# Altium schematic sheet EEG_schematic.SchDoc(EEG_schematic) (continued)

## Library data of the parts
C1 C4 C6 C15 C16 = Cap: Capacitor; Manufacturer Part Number: GRM153R61A105ME95D; Manufacturer: Murata; Voltage Rating (DC): 10V; Tolerance: 20%; Material: Ceramic; Dielectric: X5R; Width: 0.5mm; Weight: 0.000212oz; Voltage Rating: 10V; Thickness: 0.013inch; Termination: SMD/SMT; Supplier Part Number 1: 490-14577-6-ND; Supplier 1: Digi-Key; Stock 1: 47503; Simulation: CAP; Signal Integrity: Cap; REACH SVHC: No SVHC; Published: 8-Jun-2000; Pricing 1: 1=0.19, 10=0.131, 100=0.0663, 500=0.04538, 1000=0.04015, 2500=0.0384, 5000=0.03491 (USD); Packaging: Tape and Reel; PackageReference: RAD-0.3; Package Quantity: 10000; PackageDescription: Radial Cap, Thru-Hole; 2 Leads; 0.3 in Pin Spacing; Mount: Surface Mount; Min Operating Temperature: -55°C; Max Operating Temperature: 85°C; Library Name: Miscellaneous Devices.IntLib; Length: 1mm; LatestRevisionNote: Re-released for DXP Platform.; LatestRevisionDate: 17-Jul-2002; Height: 0.3mm; Composition: Ceramic; ComponentLink1URL: http://www.farnell.com/datasheets/1666664.pdf?src-supplier=Element14; ComponentLink1Description: http://www.farnell.com/datasheets/1666664.pdf?src-supplier=Element14; Component Kind: Standard; Case Code (Metric): 1005; Case Code (Imperial): 0402; Case/Package: 0402; Capacitance: 1uF; Publisher: Altium Limited
C2 C5 C7 C10 C12 C18 = Cap: Capacitor; Manufacturer Part Number: CL05A104KP5NNNC; Manufacturer: Samsung; Voltage Rating: 10V; Tolerance: 10%; Dielectric: X5R; Capacitance: 100nF; Width: 0.02inch; Thickness: 0.022inch; Supplier Part Number 1: 1276-1022-6-ND; Supplier 1: Digi-Key; Stock 1: 13743377; Simulation: CAP; Signal Integrity: Cap; Radiation Hardening: No; Published: 8-Jun-2000; Pricing 1: 1=0.1, 10=0.025, 100=0.0111, 500=0.00794, 1000=0.00624, 2500=0.00568, 5000=0.00522 (USD); Packaging: Tape and Reel; PackageReference: RAD-0.3; PackageDescription: Radial Cap, Thru-Hole; 2 Leads; 0.3 in Pin Spacing; Mount: Surface Mount; Min Operating Temperature: -55°C; Max Operating Temperature: 85°C; Library Name: Miscellaneous Devices.IntLib; Length: 1mm; LatestRevisionNote: Re-released for DXP Platform.; LatestRevisionDate: 17-Jul-2002; Height: 0.5mm; Depth: 0.5mm; Contact Plating: Tin; ComponentLink4URL: https://www.distrelec.biz/Web/Downloads/_e/ng/MLCC_CatalogDownload2013_ENG.pdf?mime=application%2Fpdf&src-supplier=Distrelec; ComponentLink4Description: https://www.distrelec.biz/Web/Downloads/_e/ng/MLCC_CatalogDownload2013_ENG.pdf?mime=application%2Fpdf&src-supplier=Distrelec; ComponentLink3URL: http://www1.futureelectronics.com/doc/SAMSUNG%20PASSIVE/CL05B104KQ5NNNC.pdf?src-supplier=Future+Electronics; ComponentLink3Description: http://www1.futureelectronics.com/doc/SAMSUNG%20PASSIVE/CL05B104KQ5NNNC.pdf?src-supplier=Future+Electronics; ComponentLink2URL: https://www.verical.com/server-webapp/html/getDatasheet?partID=358611&src-supplier=Verical; ComponentLink2Description: https://www.verical.com/server-webapp/html/getDatasheet?partID=358611&src-supplier=Verical; ComponentLink1URL: https://media.digikey.com/pdf/Data%20Sheets/Samsung%20PDFs/CL_Series_MLCC_ds.pdf?src-supplier=Digi-Key; ComponentLink1Description: https://media.digikey.com/pdf/Data%20Sheets/Samsung%20PDFs/CL_Series_MLCC_ds.pdf?src-supplier=Digi-Key; Component Kind: Standard; Case Code (Metric): 1005; Case Code (Imperial): 0402; Case/Package: 0402; Publisher: Altium Limited
C3 = Cap: Capacitor; Manufacturer Part Number: CL05B152KB5NNNC; Manufacturer: Samsung; Voltage Rating: 50V; Tolerance: 10%; Material: Ceramic; Dielectric: X7R; Width: 0.02inch; Voltage: 50V; Thickness: 0.022inch; Supplier Part Number 1: 1276-1521-6-ND; Supplier 1: Digi-Key; Stock 1: 680460; Simulation: CAP; Signal Integrity: Cap; Radiation Hardening: No; Published: 8-Jun-2000; Pricing 1: 1=0.1, 10=0.023, 100=0.0101, 500=0.0072, 1000=0.00566, 2500=0.00514, 5000=0.00473 (USD); Packaging: Tape and Reel; PackageReference: RAD-0.3; Package Quantity: 1; PackageDescription: Radial Cap, Thru-Hole; 2 Leads; 0.3 in Pin Spacing; Mount: Surface Mount; Min Operating Temperature: -55°C; Max Operating Temperature: 125°C; Library Name: Miscellaneous Devices.IntLib; Length: 1mm; LatestRevisionNote: Re-released for DXP Platform.; LatestRevisionDate: 17-Jul-2002; Height: 0.5mm; Depth: 0.5mm; Contact Plating: Tin; ComponentLink4URL: https://produktinfo.conrad.com/datenblaetter/450000-474999/459134-da-01-en-VS_KONDENSATOR_0402.pdf?src-supplier=Conrad; ComponentLink4Description: https://produktinfo.conrad.com/datenblaetter/450000-474999/459134-da-01-en-VS_KONDENSATOR_0402.pdf?src-supplier=Conrad; ComponentLink3URL: https://www.distrelec.biz/Web/Downloads/_e/ng/MLCC_CatalogDownload2013_ENG.pdf?mime=application%2Fpdf&src-supplier=Distrelec; ComponentLink3Description: https://www.distrelec.biz/Web/Downloads/_e/ng/MLCC_CatalogDownload2013_ENG.pdf?mime=application%2Fpdf&src-supplier=Distrelec; ComponentLink2URL: http://www1.futureelectronics.com/doc/SAMSUNG/CL05B152KB5NNNC.pdf?src-supplier=Future+Electronics; ComponentLink2Description: http://www1.futureelectronics.com/doc/SAMSUNG/CL05B152KB5NNNC.pdf?src-supplier=Future+Electronics; ComponentLink1URL: https://www.verical.com/server-webapp/html/getDatasheet?partID=543173&src-supplier=Verical; ComponentLink1Description: https://www.verical.com/server-webapp/html/getDatasheet?partID=543173&src-supplier=Verical; Component Kind: Standard; Case Code (Metric): 1005; Case Code (Imperial): 0402; Case/Package: 0402; Capacitance: 1.5nF; Publisher: Altium Limited
C8 C13 C14 = Cap: Capacitor; Manufacturer Part Number: GRM155R61A475MEAAD; Manufacturer: Murata; Voltage Rating: 10V; Tolerance: 20%; Supplier Part Number 1: 490-14306-6-ND; Supplier 1: Digi-Key; Stock 1: 986807; Simulation: CAP; Signal Integrity: Cap; Published: 8-Jun-2000; Pricing 1: 1=0.25, 10=0.169, 100=0.0856, 500=0.05854, 1000=0.05179, 2500=0.04954, 5000=0.04503 (USD); Packaging: Tape and Reel; PackageReference: RAD-0.3; PackageDescription: Radial Cap, Thru-Hole; 2 Leads; 0.3 in Pin Spacing; Mount: Surface Mount; Min Operating Temperature: -55°C; Max Operating Temperature: 85°C; Library Name: Miscellaneous Devices.IntLib; LatestRevisionNote: Re-released for DXP Platform.; LatestRevisionDate: 17-Jul-2002; ComponentLink10URL: http://datasheet.octopart.com/GRM155R61A475MEAAD-Murata-datasheet-12515295.pdf; ComponentLink10Description: http://datasheet.octopart.com/GRM155R61A475MEAAD-Murata-datasheet-12515295.pdf; ComponentLink9URL: http://datasheet.octopart.com/GRM155R61A475MEAAD-Murata-datasheet-12510076.pdf; ComponentLink9Description: http://datasheet.octopart.com/GRM155R61A475MEAAD-Murata-datasheet-12510076.pdf; ComponentLink8URL: http://datasheet.octopart.com/GRM155R61A475MEAAD-Murata-datasheet-11978611.pdf; ComponentLink8Description: http://datasheet.octopart.com/GRM155R61A475MEAAD-Murata-datasheet-11978611.pdf; ComponentLink7URL: http://datasheet.octopart.com/GRM155R61A475MEAAD-Murata-datasheet-10025737.pdf; ComponentLink7Description: http://datasheet.octopart.com/GRM155R61A475MEAAD-Murata-datasheet-10025737.pdf; ComponentLink6URL: http://datasheet.octopart.com/GRM155R61A475MEAAD-Murata-datasheet-8819587.pdf; ComponentLink6Description: http://datasheet.octopart.com/GRM155R61A475MEAAD-Murata-datasheet-8819587.pdf; ComponentLink5URL: http://datasheet.octopart.com/GRM155R61A475MEAAD-Murata-datasheet-68589269.pdf?src-supplier=Newark; ComponentLink5Description: http://datasheet.octopart.com/GRM155R61A475MEAAD-Murata-datasheet-68589269.pdf?src-supplier=Newark; ComponentLink4URL: http://www.farnell.com/datasheets/2189109.pdf?src-supplier=Newark; ComponentLink4Description: http://www.farnell.com/datasheets/2189109.pdf?src-supplier=Newark; ComponentLink3URL: http://www.farnell.com/datasheets/2189109.pdf?src-supplier=Element14; ComponentLink3Description: http://www.farnell.com/datasheets/2189109.pdf?src-supplier=Element14; ComponentLink2URL: https://search.murata.co.jp/Ceramy/image/img/A01X/G101/ENG/GRM155R61A475MEAA-01.pdf?src-supplier=Digi-Key; ComponentLink2Description: https://search.murata.co.jp/Ceramy/image/img/A01X/G101/ENG/GRM155R61A475MEAA-01.pdf?src-supplier=Digi-Key; ComponentLink1URL: http://www.farnell.com/datasheets/2189109.pdf?src-supplier=Farnell; ComponentLink1Description: http://www.farnell.com/datasheets/2189109.pdf?src-supplier=Farnell; Component Kind: Standard; Case Code (Metric): 1005; Case Code (Imperial): 0402; Case/Package: 0402; Capacitance: 4.7uF; Publisher: Altium Limited
C9 C11 C17 C22 = Cap: Capacitor; Manufacturer Part Number: CL05A106MP5NUNC; Manufacturer: Samsung; Voltage Rating: 10V; Tolerance: 20%; Dielectric: X5R; Width: 0.02inch; Thickness: 0.028inch; Termination: Wraparound; Supplier Part Number 1: 1276-1450-6-ND; Supplier 1: Digi-Key; Stock 1: 3732371; Simulation: CAP; Signal Integrity: Cap; Radiation Hardening: No; Published: 8-Jun-2000; Pricing 1: 1=0.42, 10=0.295, 100=0.1943, 500=0.14392, 1000=0.12233, 2500=0.11514, 5000=0.10794 (USD); Packaging: Tape and Reel; PackageReference: RAD-0.3; Package Quantity: 1; PackageDescription: Radial Cap, Thru-Hole; 2 Leads; 0.3 in Pin Spacing; Mount: Surface Mount; Min Operating Temperature: -55°C; Max Operating Temperature: 85°C; Library Name: Miscellaneous Devices.IntLib; Length: 1mm; Lead Free: Lead Free; LatestRevisionNote: Re-released for DXP Platform.; LatestRevisionDate: 17-Jul-2002; Depth: 0.5mm; Contact Plating: Tin; ComponentLink2URL: https://www.verical.com/server-webapp/html/getDatasheet?partID=745310&src-supplier=Verical; ComponentLink2Description: https://www.verical.com/server-webapp/html/getDatasheet?partID=745310&src-supplier=Verical; ComponentLink1URL: https://media.digikey.com/pdf/Data%20Sheets/Samsung%20PDFs/CL_Series_MLCC_ds.pdf?src-supplier=Digi-Key; ComponentLink1Description: https://media.digikey.com/pdf/Data%20Sheets/Samsung%20PDFs/CL_Series_MLCC_ds.pdf?src-supplier=Digi-Key; Component Kind: Standard; Case Code (Metric): 1005; Case Code (Imperial): 0402; Case/Package: 0402; Capacitance: 10uF; Publisher: Altium Limited
C19 C23 = Cap: Capacitor; Manufacturer Part Number: GRM31CR60J107ME39L; Manufacturer: Murata; Voltage Rating (DC): 6.3V; Tolerance: 20%; Material: Ceramic; Dielectric: X5R; Width: 1.6mm; Voltage Rating: 6.3V; Voltage: 6.3V; Thickness: 0.071inch; Termination: SMD/SMT; Supplier Part Number 1: 490-4539-6-ND; Supplier 1: Digi-Key; Stock 1: 1563525; Simulation: CAP; Signal Integrity: Cap; REACH SVHC: No SVHC; Radiation Hardening: No; Published: 8-Jun-2000; Pricing 1: 1=1.08, 10=0.852, 100=0.6386, 500=0.48254, 1000=0.42577 (USD); Packaging: Tape and Reel; PackageReference: RAD-0.3; Package Quantity: 1; PackageDescription: Radial Cap, Thru-Hole; 2 Leads; 0.3 in Pin Spacing; Mount: Surface Mount; Min Operating Temperature: -55°C; Max Operating Temperature: 85°C; Library Name: Miscellaneous Devices.IntLib; Length: 3.2mm; Lead Free: Lead Free; LatestRevisionNote: Re-released for DXP Platform.; LatestRevisionDate: 17-Jul-2002; Height: 1.6mm; Depth: 1.6mm; ComponentLink8URL: http://www.produktinfo.conrad.com/datenblaetter/450000-474999/451152-da-01-de-VS_CHIP_KOND_1206_100_0_UF_20Pr_6_3V_X5R.pdf?src-supplier=Conrad; ComponentLink8Description: http://www.produktinfo.conrad.com/datenblaetter/450000-474999/451152-da-01-de-VS_CHIP_KOND_1206_100_0_UF_20Pr_6_3V_X5R.pdf?src-supplier=Conrad; ComponentLink7URL: http://www.produktinfo.conrad.com/datenblaetter/450000-474999/454804-da-01-en-VS_KONDENSATOR_1206.pdf?src-supplier=Conrad; ComponentLink7Description: http://www.produktinfo.conrad.com/datenblaetter/450000-474999/454804-da-01-en-VS_KONDENSATOR_1206.pdf?src-supplier=Conrad; ComponentLink6URL: https://www.distrelec.biz/Web/Downloads/_t/ds/murata_MLCC_eng_tds.pdf?mime=application%2Fpdf&src-supplier=Distrelec; ComponentLink6Description: https://www.distrelec.biz/Web/Downloads/_t/ds/murata_MLCC_eng_tds.pdf?mime=application%2Fpdf&src-supplier=Distrelec; ComponentLink5URL: http://psearch.murata.com/capacitor/product/GRM31CF51A226ZE01%23.pdf?src-supplier=Future+Electronics; ComponentLink5Description: http://psearch.murata.com/capacitor/product/GRM31CF51A226ZE01%23.pdf?src-supplier=Future+Electronics; ComponentLink4URL: https://www.murata.com/~/media/webrenewal/support/library/catalog/products/capacitor/mlcc/c02e.ashx?la=en-us&src-supplier=Digi-Key; ComponentLink4Description: https://www.murata.com/~/media/webrenewal/support/library/catalog/products/capacitor/mlcc/c02e.ashx?la=en-us&src-supplier=Digi-Key; ComponentLink3URL: http://www.mouser.com/ds/2/281/c02e-2905.pdf?src-supplier=Mouser; ComponentLink3Description: http://www.mouser.com/ds/2/281/c02e-2905.pdf?src-supplier=Mouser; ComponentLink2URL: http://components.arrow.com/part/dataview/42788059S8888100N2606?src-supplier=Arrow; ComponentLink2Description: http://components.arrow.com/part/dataview/42788059S8888100N2606?src-supplier=Arrow; ComponentLink1URL: http://uk.rs-online.com/webdocs/1388/0900766b813885c5.pdf?src-supplier=RSComponents; ComponentLink1Description: http://uk.rs-online.com/webdocs/1388/0900766b813885c5.pdf?src-supplier=RSComponents; Component Kind: Standard; Case Code (Metric): 3216; Case Code (Imperial): 1206; Case/Package: 1206; Capacitance: 100uF; Publisher: Altium Limited
C20 C21 = Cap: Capacitor; Manufacturer Part Number: C0402C180K5RAC7867; Manufacturer: KEMET; Supplier Part Number 1: 399-19371-6-ND; Supplier 1: Digi-Key; Stock 1: 3803; Simulation: CAP; Signal Integrity: Cap; Published: 8-Jun-2000; Pricing 1: 1=0.21, 10=0.142, 100=0.0721, 500=0.04934, 1000=0.04364, 2500=0.04174, 5000=0.03795 (USD); PackageReference: RAD-0.3; PackageDescription: Radial Cap, Thru-Hole; 2 Leads; 0.3 in Pin Spacing; Library Name: Miscellaneous Devices.IntLib; LatestRevisionNote: Re-released for DXP Platform.; LatestRevisionDate: 17-Jul-2002; Component Kind: Standard; Publisher: Altium Limited
D1 = GSOT04C-G3-08: TVS Diode Arrays 4.0 Volt 300 Watt Common Anode; Manufacturer: Vishay Semiconductors; Reverse Standoff Voltage: 4V; Power Line Protection: No; Polarity: Bidirectional; Peak Pulse Power: 429W; Peak Pulse Current: 30A; Number of Unidirectional Channels: 2; Min Breakdown Voltage: 5V; Clamping Voltage: 14.3V; Capacitance: 200pF; Breakdown Voltage: 6.1V; Weight: 0.00031oz; Termination: SMD/SMT; Supplier Part Number 1: GSOT04C-G3-08GIDKR-ND; Supplier 1: Digi-Key; Stock 1: 3725; Pricing 1: 1=0.43, 10=0.324, 25=0.2916, 100=0.1538, 250=0.15224, 500=0.13634, 1000=0.1061 (USD); Packaging: Tape and Reel; Number of Channels: 2; Mount: Surface Mount; Min Operating Temperature: -55°C; Max Operating Temperature: 150°C; Manufacturer Part Number: GSOT04C-G3-08; Library Name: SchlibEEG.SchLib; Composition: Zener; ComponentLink2URL: http://www.vishay.com/docs/85824/gsot03c.pdf?src-supplier=Digi-Key; ComponentLink2Description: http://www.vishay.com/docs/85824/gsot03c.pdf?src-supplier=Digi-Key; ComponentLink1URL: http://www.mouser.com/ds/2/427/gsot03c-243311.pdf?src-supplier=Mouser; ComponentLink1Description: http://www.mouser.com/ds/2/427/gsot03c-243311.pdf?src-supplier=Mouser; Component Kind: Standard; Case/Package: TO-236-3
D2 = LED1: LED BLUE CLEAR CHIP SMD; Manufacturer Part Number: LTST-C193TBKT-5A; Manufacturer: Vishay Lite-On; Viewing Angle: 130°; Test Current: 5mA; Reverse Voltage: 5V; Power Dissipation: 76mW; Number of LEDs: 1; Luminous Intensity: 15mcd; Lens Style: Diffused,Colorless,Rectangular,Clear; Lens Color: Colorless; Forward Voltage: 2.8V; Forward Current: 20mA; Dominant Wavelength: 475nm; Width: 0.8mm; Supplier Part Number 1: 160-1827-1-ND; Supplier 1: Digi-Key; Stock 1: 40029; Radiation Hardening: No; Published: 8-Jun-2000; Pricing 1: 1=0.45, 10=0.32, 100=0.1424, 500=0.09968, 1000=0.08188, 2500=0.0712 (USD); Packaging: Tape and Reel; PackageReference: LED-1; Package Quantity: 1; PackageDescription: LED; 2 Leads; Number of Pins: 2; Number of Elements: 1; Mount: Surface Mount; Min Operating Temperature: -20°C; Max Operating Temperature: 80°C; Library Name: Miscellaneous Devices.IntLib; Lens Transparency: Clear; Length: 1.6mm; Lead Free: Lead Free; LatestRevisionNote: Mech Layer 1 removed.; LatestRevisionDate: 08-Jul-2005; Illumination Color: Blue; Height: 0.35mm; Depth: 0.8mm; ComponentLink5URL: http://www1.futureelectronics.com/doc/LITE-ON/LTST-C193TBKT-5A.pdf?src-supplier=Future+Electronics; ComponentLink5Description: http://www1.futureelectronics.com/doc/LITE-ON/LTST-C193TBKT-5A.pdf?src-supplier=Future+Electronics; ComponentLink4URL: http://uk.rs-online.com/webdocs/0dc0/0900766b80dc0554.pdf?src-supplier=RSComponents; ComponentLink4Description: http://uk.rs-online.com/webdocs/0dc0/0900766b80dc0554.pdf?src-supplier=RSComponents; ComponentLink3URL: https://www.verical.com/server-webapp/html/getDatasheet?partID=293056&src-supplier=Verical; ComponentLink3Description: https://www.verical.com/server-webapp/html/getDatasheet?partID=293056&src-supplier=Verical; ComponentLink2URL: https://media.digikey.com/pdf/Data%20Sheets/Lite-On%20PDFs/LTST-C193TBKT-5A.pdf?src-supplier=Digi-Key; ComponentLink2Description: https://media.digikey.com/pdf/Data%20Sheets/Lite-On%20PDFs/LTST-C193TBKT-5A.pdf?src-supplier=Digi-Key; ComponentLink1URL: http://www.mouser.com/ds/2/239/Lite-On-LTST-C193TBKT-5A-187386.pdf?src-supplier=Mouser; ComponentLink1Description: http://www.mouser.com/ds/2/239/Lite-On-LTST-C193TBKT-5A-187386.pdf?src-supplier=Mouser; Component Kind: Standard; Color: Blue; Case Code (Metric): 1608; Case Code (Imperial): 0603; Case/Package: 0603; Publisher: Altium Limited
J1 = B2B-PH-SM4-TBT(LF)(SN): Manufacturer: JST; Pitch: 0.079inch; Number of Positions: 2; Connector Type: Male Pin; Termination: Solder; Supplier Part Number 1: 455-2872-6-ND; Supplier 1: Digi-Key; Stock 1: 6672; Pricing 1: 1=0.82, 10=0.768, 100=0.5888, 500=0.51202 (USD); Packaging: Cut Tape; Package Quantity: 1; Number of Rows: 1; Mount: Surface Mount; Manufacturer Part Number: B2B-PH-SM4-TBT(LF)(SN); Library Name: SchlibEEG.SchLib; Lead Free: Lead Free; Fastening Type: Detent; Description: CONN HEADER SMD 2POS 2MM; Contact Plating: Tin; Contact Mating Length: 0.13inch; ComponentLink2URL: http://www.jst-mfg.com/product/pdf/eng/ePH.pdf?src-supplier=Digi-Key; ComponentLink2Description: http://www.jst-mfg.com/product/pdf/eng/ePH.pdf?src-supplier=Digi-Key; ComponentLink1URL: https://www.verical.com/server-webapp/html/getDatasheet?partID=473167&src-supplier=Verical; ComponentLink1Description: https://www.verical.com/server-webapp/html/getDatasheet?partID=473167&src-supplier=Verical; Component Kind: Standard; Color: Natural
J2 = 61301211121: WURTH ELEKTRONIK         61301211121            Board-To-Board Connector, Vertical, WR-PHD Series, Through Hole, Header, 12 Contacts, 2.54 mm; Manufacturer: Wurth Electronics; Pitch: 0.1inch; Number of Positions: 12; Gender: Male; Connector Type: Male Pin; Termination: Solder; Supplier Part Number 1: 732-5323-ND; Supplier 1: Digi-Key; Stock 1: 334; REACH SVHC: Unknown; Pricing 1: 1=0.54, 10=0.454, 25=0.3792, 50=0.316, 100=0.287, 1000=0.261 (USD); Packaging: Bulk; Number of Rows: 1; Number of Contacts: 12; Mount: Through Hole; Manufacturer Part Number: 61301211121; Contact Plating: Gold; Contact Mating Length: 0.236inch; Contact Material: Copper; ComponentLink2URL: https://katalog.we-online.de/em/datasheet/6130xx11121.pdf?src-supplier=Digi-Key; ComponentLink2Description: https://katalog.we-online.de/em/datasheet/6130xx11121.pdf?src-supplier=Digi-Key; ComponentLink1URL: http://www.farnell.com/cad/1737268.pdf?src-supplier=Element14; ComponentLink1Description: http://www.farnell.com/cad/1737268.pdf?src-supplier=Element14; Component Kind: Standard; Color: Black; Library Name: daniel_schlib.schlib
J3 = MEM2067-02-180-00-A: GLOBAL CONNECTOR TECHNOLOGY         MEM2067-02-180-00-A             MEMORY CARD CONNECTOR, 8 POSITION; Number of Contacts: 8; Supplier Part Number 1: 2073-MEM2067-02-180-00-ACT-ND; Supplier 1: Digi-Key; Stock 1: 0; REACH SVHC: No SVHC; Mount: Socket; Manufacturer Part Number: MEM2067-02-180-00-A; Manufacturer: Global Connector Technology; Library Name: SchlibEEG.SchLib; Lead Free: Lead Free; Contact Plating: Gold; Contact Material: Copper Alloy; ComponentLink2URL: http://www.farnell.com/datasheets/1876941.pdf?src-supplier=Farnell; ComponentLink2Description: http://www.farnell.com/datasheets/1876941.pdf?src-supplier=Farnell; ComponentLink1URL: http://www.farnell.com/datasheets/1876941.pdf?src-supplier=Element14; ComponentLink1Description: http://www.farnell.com/datasheets/1876941.pdf?src-supplier=Element14; Component Kind: Standard
J4 = 61300621121: WURTH ELEKTRONIK         61300621121            Board-To-Board Connector, Vertical, WR-PHD Series, Through Hole, Header, 6 Contacts, 2.54 mm; Manufacturer: Wurth Electronics; Row Spacing: 0.1inch; Number of Positions: 6; Gender: Male; Connector Type: Male Pin; Termination: Solder; Supplier Part Number 1: 732-5295-ND; Supplier 1: Digi-Key; Stock 1: 2655; REACH SVHC: Unknown; Pricing 1: 1=0.54, 10=0.454, 25=0.3792, 50=0.316, 100=0.287, 1000=0.261 (USD); Pitch: 0.1inch; Packaging: Bulk; Number of Rows: 2; Number of Contacts: 6; Mount: Through Hole; Manufacturer Part Number: 61300621121; Library Name: SchlibEEG.SchLib; Contact Plating: Gold; Contact Mating Length: 0.236inch; Contact Material: Copper; ComponentLink1URL: http://www.farnell.com/datasheets/1442180.pdf?src-supplier=Element14; ComponentLink1Description: http://www.farnell.com/datasheets/1442180.pdf?src-supplier=Element14; Component Kind: Standard; Color: Black
L1 = Inductor: Manufacturer Part Number: MLZ1608A2R2WT000; Manufacturer: TDK; Tolerance: 20%; Test Frequency: 10MHz; Shielding: Shielded; Self Resonant Frequency: 120MHz; Saturation Current: 130mA; DC Resistance (DCR): 325mR; Current Rating: 500mA; Core Material: Ferrite; Code_IEC: 1005; Width: 0.031inch; Supplier Part Number 1: 445-6385-6-ND; Supplier 1: Digi-Key; Stock 1: 3233; Simulation: INDUCTOR; Signal Integrity: Inductor; Published: 8-Jun-2000; Pricing 1: 1=0.17, 10=0.155, 100=0.1035, 500=0.08626, 1000=0.07245 (USD); Packaging: Tape and Reel; PackageReference: 0402-A; Package Quantity: 4000; PackageDescription: Chip Inductor; Mount: Surface Mount; Min Operating Temperature: -55°C; Max Operating Temperature: 125°C; Library Name: Miscellaneous Devices.IntLib; Length: 0.063inch; Lead Free: Lead Free; LatestRevisionNote: IPC-7351 Footprint Added.; LatestRevisionDate: 29-May-2009; Inductance: 2.2uH; Height - Seated (Max): 0.037inch; Description: Inductor; ComponentLink1URL: https://product.tdk.com/info/en/catalog/datasheets/inductor_commercial_decoupling_mlz1608_en.pdf?src-supplier=Digi-Key; ComponentLink1Description: https://product.tdk.com/info/en/catalog/datasheets/inductor_commercial_decoupling_mlz1608_en.pdf?src-supplier=Digi-Key; Component Kind: Standard; Code_JEITA: 0402; Case Code (Metric): 1608; Case Code (Imperial): 0603; Case/Package: 0603; Publisher: Altium Limited
L2 = Inductor: Manufacturer Part Number: MLZ1608M4R7WT000; Manufacturer: TDK; Tolerance: 20%; Test Frequency: 2MHz; Shielding: Shielded; Self Resonant Frequency: 80MHz; Saturation Current: 120mA; DC Resistance (DCR): 650mR; Current Rating: 350mA; Core Material: Ferrite; Code_IEC: 1005; Width: 0.031inch; Supplier Part Number 1: 445-6390-6-ND; Supplier 1: Digi-Key; Stock 1: 1843; Simulation: INDUCTOR; Signal Integrity: Inductor; Published: 8-Jun-2000; Pricing 1: 1=0.17, 10=0.155, 100=0.1035, 500=0.08626, 1000=0.07245 (USD); Packaging: Tape and Reel; PackageReference: 0402-A; Package Quantity: 4000; PackageDescription: Chip Inductor; Mount: Surface Mount; Min Operating Temperature: -55°C; Max Operating Temperature: 125°C; Library Name: Miscellaneous Devices.IntLib; Length: 0.063inch; LatestRevisionNote: IPC-7351 Footprint Added.; LatestRevisionDate: 29-May-2009; Inductance: 4.7uH; Height - Seated (Max): 0.037inch; Description: Inductor; ComponentLink1URL: https://product.tdk.com/info/en/catalog/datasheets/inductor_commercial_decoupling_mlz1608_en.pdf?src-supplier=Digi-Key; ComponentLink1Description: https://product.tdk.com/info/en/catalog/datasheets/inductor_commercial_decoupling_mlz1608_en.pdf?src-supplier=Digi-Key; Component Kind: Standard; Code_JEITA: 0402; Case Code (Metric): 1608; Case Code (Imperial): 0603; Case/Package: 0603; Publisher: Altium Limited
R1 R16 = Res1: Resistor; Manufacturer Part Number: RC0402FR-071ML; Manufacturer: Yageo; Voltage Rating: 50V; Tolerance: 1%; Temperature Coefficient: 100ppm/°C; Resistance: 1MR; Power Rating: 63mW; Termination: SMD/SMT; Supplier Part Number 1: 311-1.00MLRCT-ND; Supplier 1: Digi-Key; Stock 1: 10385243; Simulation: RESISTOR; Signal Integrity: Res; REACH SVHC: Unknown; Published: 8-Jun-2000; Pricing 1: 1=0.1, 10=0.016, 100=0.0064, 1000=0.00289, 2500=0.00251, 5000=0.00207 (USD); Packaging: Tape and Reel; PackageReference: AXIAL-0.3; PackageDescription: Axial Device, Thru-Hole; 2 Leads; 0.3 in Pin Spacing; Min Operating Temperature: -55°C; Military Standard: Not; Max Operating Temperature: 155°C; Library Name: Miscellaneous Devices.IntLib; Length: 1mm; Lead Free: Lead Free; LatestRevisionNote: Re-released for DXP Platform.; LatestRevisionDate: 17-Jul-2002; Height: 0.32mm; Depth: 0.5mm; Composition: Thick Film; ComponentLink3URL: http://www.farnell.com/datasheets/2167052.pdf?src-supplier=Element14; ComponentLink3Description: http://www.farnell.com/datasheets/2167052.pdf?src-supplier=Element14; ComponentLink2URL: http://www.yageo.com/documents/recent/PYu-RC0402_51_RoHS_L_6_r.pdf?src-supplier=Future+Electronics; ComponentLink2Description: http://www.yageo.com/documents/recent/PYu-RC0402_51_RoHS_L_6_r.pdf?src-supplier=Future+Electronics; ComponentLink1URL: http://www.farnell.com/datasheets/1018594.pdf?src-supplier=Element14; ComponentLink1Description: http://www.farnell.com/datasheets/1018594.pdf?src-supplier=Element14; Component Kind: Standard; Case Code (Metric): 1005; Case Code (Imperial): 0402; Case/Package: 0402; Publisher: Altium Limited
R2 R3 = Res1: Resistor; Manufacturer Part Number: RC0402JR-070RL; Manufacturer: Yageo; Voltage Rating: 50V; Tolerance: 5%; Temperature Coefficient: 200ppm/°C; Resistance: 0mR; Power Rating: 62.5mW; Max Power Dissipation: 63mW; Width: 0.02inch; Termination: SMD/SMT; Supplier Part Number 1: 311-0.0JRDKR-ND; Supplier 1: Digi-Key; Stock 1: 48667834; Simulation: RESISTOR; Signal Integrity: Res; REACH SVHC: Unknown; Radiation Hardening: No; Published: 8-Jun-2000; Pricing 1: 1=0.1, 10=0.013, 25=0.0092, 50=0.0072, 100=0.0053, 250=0.004, 500=0.0032, 1000=0.00236, 5000=0.00169 (USD); Packaging: Tape and Reel; PackageReference: AXIAL-0.3; Package Quantity: 1; PackageDescription: Axial Device, Thru-Hole; 2 Leads; 0.3 in Pin Spacing; Number of Terminations: 2; Min Operating Temperature: -55°C; Military Standard: Not; Max Operating Temperature: 155°C; Library Name: Miscellaneous Devices.IntLib; Length: 1mm; Lead Free: Lead Free; LatestRevisionNote: Re-released for DXP Platform.; LatestRevisionDate: 17-Jul-2002; Height: 0.016inch; Features: Moisture Resistant; Depth: 0.5mm; Contact Plating: Tin,Matte; Composition: Thick Film; ComponentLink5URL: http://www.farnell.com/datasheets/2167052.pdf?src-supplier=Element14; ComponentLink5Description: http://www.farnell.com/datasheets/2167052.pdf?src-supplier=Element14; ComponentLink4URL: https://www.verical.com/server-webapp/html/getDatasheet?partID=326379&src-supplier=Verical; ComponentLink4Description: https://www.verical.com/server-webapp/html/getDatasheet?partID=326379&src-supplier=Verical; ComponentLink3URL: http://www.farnell.com/datasheets/1018594.pdf?src-supplier=Element14; ComponentLink3Description: http://www.farnell.com/datasheets/1018594.pdf?src-supplier=Element14; ComponentLink2URL: http://www.yageo.com/documents/recent/PYu-RC0402_51_RoHS_L_6.pdf?src-supplier=Avnet+Europe; ComponentLink2Description: http://www.yageo.com/documents/recent/PYu-RC0402_51_RoHS_L_6.pdf?src-supplier=Avnet+Europe; ComponentLink1URL: http://www.yageo.com/documents/recent/PYu-RC_Group_51_RoHS_L_10.pdf?src-supplier=Digi-Key; ComponentLink1Description: http://www.yageo.com/documents/recent/PYu-RC_Group_51_RoHS_L_10.pdf?src-supplier=Digi-Key; Component Kind: Standard; Case Code (Metric): 1005; Case Code (Imperial): 0402; Case/Package: 0402; Publisher: Altium Limited
R4 = Res1: Resistor; Manufacturer Part Number: RC0402FR-07453KL; Manufacturer: Yageo; Voltage Rating (DC): 50V; Tolerance: 1%; Temperature Coefficient: 100ppm/°C; Resistance: 453kR; Power Rating: 62.5mW; Max Power Dissipation: 63mW; Width: 0.02inch; Voltage Rating: 50V; Termination: SMD/SMT; Supplier Part Number 1: YAG3164DKR-ND; Supplier 1: Digi-Key; Stock 1: 786377; Simulation: RESISTOR; Signal Integrity: Res; Published: 8-Jun-2000; Pricing 1: 1=0.1, 10=0.016, 100=0.0064, 1000=0.00289, 2500=0.00251, 5000=0.00207 (USD); Packaging: Tape and Reel; PackageReference: AXIAL-0.3; PackageDescription: Axial Device, Thru-Hole; 2 Leads; 0.3 in Pin Spacing; Number of Terminations: 2; Min Operating Temperature: -55°C; Military Standard: Not; Max Operating Temperature: 155°C; Library Name: Miscellaneous Devices.IntLib; Length: 1mm; Lead Free: Lead Free; LatestRevisionNote: Re-released for DXP Platform.; LatestRevisionDate: 17-Jul-2002; Height: 0.016inch; Features: Moisture Resistant; Depth: 0.5mm; Contact Plating: Tin,Matte; Composition: Thick Film; ComponentLink4URL: http://www.yageo.com/documents/recent/PYu-RC0402_51_RoHS_L_6.pdf?src-supplier=Avnet+Europe; ComponentLink4Description: http://www.yageo.com/documents/recent/PYu-RC0402_51_RoHS_L_6.pdf?src-supplier=Avnet+Europe; ComponentLink3URL: http://www.yageo.com/documents/recent/PYu-RC0402_51_RoHS_L_6.pdf?src-supplier=Avnet; ComponentLink3Description: http://www.yageo.com/documents/recent/PYu-RC0402_51_RoHS_L_6.pdf?src-supplier=Avnet; ComponentLink2URL: https://www.verical.com/server-webapp/html/getDatasheet?partID=325135&src-supplier=Verical; ComponentLink2Description: https://www.verical.com/server-webapp/html/getDatasheet?partID=325135&src-supplier=Verical; ComponentLink1URL: http://www.yageo.com/documents/recent/PYu-RC0402_51_RoHS_L_6_r.pdf?src-supplier=Future+Electronics; ComponentLink1Description: http://www.yageo.com/documents/recent/PYu-RC0402_51_RoHS_L_6_r.pdf?src-supplier=Future+Electronics; Component Kind: Standard; Case Code (Metric): 1005; Case Code (Imperial): 0402; Case/Package: 0402; Publisher: Altium Limited
R5-R8 R11 = Res1: Resistor; Manufacturer Part Number: RC0402FR-07100KL; Manufacturer: Yageo; Working Voltage: 50V; Tolerance: 1%; Temperature Coefficient: 100ppm/°C; Resistance: 100kR; Power Rating: 63mW; Width: 0.02inch; Voltage Rating: 50V; Termination: SMD/SMT; Supplier 1: Digi-Key; Simulation: RESISTOR; Signal Integrity: Res; REACH SVHC: No SVHC; Published: 8-Jun-2000; Packaging: Tape and Reel; PackageReference: AXIAL-0.3; Package Quantity: 1; PackageDescription: Axial Device, Thru-Hole; 2 Leads; 0.3 in Pin Spacing; Number of Terminations: 2; Min Operating Temperature: -55°C; Military Standard: Not; Max Power Dissipation: 63mW; Max Operating Temperature: 155°C; Library Name: Miscellaneous Devices.IntLib; Length: 0.039inch; Lead Free: Lead Free; LatestRevisionNote: Re-released for DXP Platform.; LatestRevisionDate: 17-Jul-2002; Height: 0.016inch; Features: Moisture Resistant; Depth: 0.5mm; Composition: Thick Film; ComponentLink5URL: http://documents.rs-components.com/techlib://itc_doclist_GB.htm?stockno=6185797&src-supplier=RSComponents; ComponentLink5Description: http://documents.rs-components.com/techlib://itc_doclist_GB.htm?stockno=6185797&src-supplier=RSComponents; ComponentLink4URL: http://www.yageo.com/documents/recent/PYu-RC_Group_51_RoHS_L_9.pdf?src-supplier=Mouser; ComponentLink4Description: http://www.yageo.com/documents/recent/PYu-RC_Group_51_RoHS_L_9.pdf?src-supplier=Mouser; ComponentLink3URL: http://www.yageo.com/documents/recent/PYu-RC_Group_51_RoHS_L_9.pdf?src-supplier=Verical; ComponentLink3Description: http://www.yageo.com/documents/recent/PYu-RC_Group_51_RoHS_L_9.pdf?src-supplier=Verical; ComponentLink2URL: http://www.farnell.com/datasheets/1018594.pdf?src-supplier=Element14; ComponentLink2Description: http://www.farnell.com/datasheets/1018594.pdf?src-supplier=Element14; ComponentLink1URL: http://www.yageo.com/documents/recent/PYu-RC_Group_51_RoHS_L_10.pdf?src-supplier=Digi-Key; ComponentLink1Description: http://www.yageo.com/documents/recent/PYu-RC_Group_51_RoHS_L_10.pdf?src-supplier=Digi-Key; Component Kind: Standard; Case Code (Metric): 1005; Case Code (Imperial): 0402; Case/Package: 0402; Publisher: Altium Limited
  R5 R7 R8 R11: Supplier Part Number 1: 311-100KLRCT-ND; Stock 1: 48151542; Pricing 1: 1=0.1, 10=0.016, 100=0.0064, 1000=0.00289, 2500=0.00251, 5000=0.00207 (USD)
  R6: Supplier Part Number 2: 311-100KLRCT-ND; Supplier Part Number 1: 311-100KLRDKR-ND; Supplier 2: Digi-Key; Stock 2: 48151542; Stock 1: 56936694; Pricing 2: 1=0.1, 10=0.016, 100=0.0064, 1000=0.00289, 2500=0.00251, 5000=0.00207 (USD); Pricing 1: 1=0.1, 10=0.015, 100=0.006, 1000=0.0027, 2500=0.00235, 5000=0.00194 (USD)
R9 = Res1: Resistor; Manufacturer Part Number: RC0402JR-071M6L; Manufacturer: Yageo; Tolerance: 5%; Temperature Coefficient: 100ppm/°C; Resistance: 1.6MR; Max Power Dissipation: 63mW; Width: 0.02inch; Supplier Part Number 1: YAG3284DKR-ND; Supplier 1: Digi-Key; Stock 1: 21969; Simulation: RESISTOR; Signal Integrity: Res; Radiation Hardening: No; Published: 8-Jun-2000; Pricing 1: 1=0.1, 10=0.013, 100=0.0053, 1000=0.00236, 2500=0.00205, 5000=0.00169 (USD); Packaging: Tape and Reel; PackageReference: AXIAL-0.3; PackageDescription: Axial Device, Thru-Hole; 2 Leads; 0.3 in Pin Spacing; Number of Terminations: 2; Min Operating Temperature: -55°C; Military Standard: Not; Max Operating Temperature: 155°C; Library Name: Miscellaneous Devices.IntLib; Length: 0.039inch; LatestRevisionNote: Re-released for DXP Platform.; LatestRevisionDate: 17-Jul-2002; Height: 0.016inch; Features: Moisture Resistant; Depth: 0.5mm; Contact Plating: Tin,Matte; Composition: Thick Film; ComponentLink2URL: http://www.yageo.com/documents/recent/PYu-RC0402_51_RoHS_L_6.pdf?src-supplier=Avnet+Europe; ComponentLink2Description: http://www.yageo.com/documents/recent/PYu-RC0402_51_RoHS_L_6.pdf?src-supplier=Avnet+Europe; ComponentLink1URL: http://www.yageo.com/documents/recent/PYu-RC0402_51_RoHS_L_6.pdf?src-supplier=Avnet; ComponentLink1Description: http://www.yageo.com/documents/recent/PYu-RC0402_51_RoHS_L_6.pdf?src-supplier=Avnet; Component Kind: Standard; Case Code (Metric): 1005; Case Code (Imperial): 0402; Case/Package: 0402; Publisher: Altium Limited
R10 = Res1: Resistor; Manufacturer Part Number: RC0402JR-07180KL; Manufacturer: Yageo; Voltage Rating (DC): 50V; Tolerance: 5%; Temperature Coefficient: 100ppm/°C; Resistance: 180kR; Power Rating: 63mW; Width: 0.5mm; Voltage Rating: 50V; Termination: SMD/SMT; Supplier Part Number 1: 311-180KJRDKR-ND; Supplier 1: Digi-Key; Stock 1: 249583; Simulation: RESISTOR; Signal Integrity: Res; REACH SVHC: Unknown; Published: 8-Jun-2000; Pricing 1: 1=0.1, 10=0.013, 100=0.0053, 1000=0.00236, 2500=0.00205, 5000=0.00169 (USD); Packaging: Tape and Reel; PackageReference: AXIAL-0.3; Package Quantity: 1; PackageDescription: Axial Device, Thru-Hole; 2 Leads; 0.3 in Pin Spacing; Number of Terminations: 2; Number of Pins: 2; Min Operating Temperature: -55°C; Military Standard: Not; Max Power Dissipation: 63mW; Max Operating Temperature: 155°C; Library Name: Miscellaneous Devices.IntLib; Length: 1mm; Lead Free: Lead Free; LatestRevisionNote: Re-released for DXP Platform.; LatestRevisionDate: 17-Jul-2002; Height: 0.016inch; Features: Moisture Resistant; Depth: 0.5mm; Composition: Thick Film; ComponentLink5URL: http://www.yageo.com.tw/exep/pages/download/literatures/PYu-R_INT-thick_7.pdf?src-supplier=Digi-Key; ComponentLink5Description: http://www.yageo.com.tw/exep/pages/download/literatures/PYu-R_INT-thick_7.pdf?src-supplier=Digi-Key; ComponentLink4URL: http://www.yageo.com/documents/recent/PYu-RC_Group_51_RoHS_L_9.pdf?src-supplier=Digi-Key; ComponentLink4Description: http://www.yageo.com/documents/recent/PYu-RC_Group_51_RoHS_L_9.pdf?src-supplier=Digi-Key; ComponentLink3URL: http://www.farnell.com/datasheets/1018594.pdf?src-supplier=Element14; ComponentLink3Description: http://www.farnell.com/datasheets/1018594.pdf?src-supplier=Element14; ComponentLink2URL: http://www.yageo.com/documents/recent/PYu-RC0402_51_RoHS_L_6_r.pdf?src-supplier=Future+Electronics; ComponentLink2Description: http://www.yageo.com/documents/recent/PYu-RC0402_51_RoHS_L_6_r.pdf?src-supplier=Future+Electronics; ComponentLink1URL: http://www.farnell.com/datasheets/1018594.pdf?src-supplier=Farnell; ComponentLink1Description: http://www.farnell.com/datasheets/1018594.pdf?src-supplier=Farnell; Component Kind: Standard; Case Code (Metric): 1005; Case Code (Imperial): 0402; Case/Package: 0402; Publisher: Altium Limited
R12 = Res1: Resistor; Manufacturer Part Number: RC0402FR-0710KL; Manufacturer: Yageo; Working Voltage: 50V; Tolerance: 1%; Temperature Coefficient: 100ppm/°C; Resistance: 10kR; Power Rating: 62.5mW; Width: 0.5mm; Voltage Rating (DC): 50V; Voltage Rating: 50V; Termination: SMD/SMT; Supplier Part Number 1: 311-10.0KLRDKR-ND; Supplier 1: Digi-Key; Stock 1: 58022711; Simulation: RESISTOR; Signal Integrity: Res; REACH SVHC: No SVHC; Published: 8-Jun-2000; Pricing 1: 1=0.1, 10=0.016, 100=0.0064, 1000=0.00289, 2500=0.00251, 5000=0.00207 (USD); Packaging: Tape and Reel; PackageReference: AXIAL-0.3; PackageDescription: Axial Device, Thru-Hole; 2 Leads; 0.3 in Pin Spacing; Number of Terminations: 2; Number of Pins: 2; Min Operating Temperature: -55°C; Military Standard: Not; Max Operating Temperature: 155°C; Library Name: Miscellaneous Devices.IntLib; Length: 1mm; Lead Pitch: 0.2mm; Lead Free: Lead Free; LatestRevisionNote: Re-released for DXP Platform.; LatestRevisionDate: 17-Jul-2002; Height: 0.32mm; Depth: 0.5mm; Composition: Thick Film; ComponentLink4URL: http://www.yageo.com/documents/recent/PYu-RC_Group_51_RoHS_L_9.pdf?src-supplier=Mouser; ComponentLink4Description: http://www.yageo.com/documents/recent/PYu-RC_Group_51_RoHS_L_9.pdf?src-supplier=Mouser; ComponentLink3URL: http://www.farnell.com/datasheets/1784430.pdf?src-supplier=Element14; ComponentLink3Description: http://www.farnell.com/datasheets/1784430.pdf?src-supplier=Element14; ComponentLink2URL: http://www.farnell.com/datasheets/1686128.pdf?src-supplier=Element14; ComponentLink2Description: http://www.farnell.com/datasheets/1686128.pdf?src-supplier=Element14; ComponentLink1URL: http://www.farnell.com/datasheets/2167052.pdf?src-supplier=Element14; ComponentLink1Description: http://www.farnell.com/datasheets/2167052.pdf?src-supplier=Element14; Component Kind: Standard; Case Code (Metric): 1005; Case Code (Imperial): 0402; Case/Package: 0402; Publisher: Altium Limited
R13 R15 = Res1: Resistor; Manufacturer Part Number: RC0402FR-071KL; Manufacturer: Yageo; Voltage Rating (DC): 50V; Tolerance: 1%; Temperature Coefficient: 100ppm/°C; Resistance: 1kR; Power Rating: 62.5mW; Width: 0.5mm; Voltage Rating: 50V; Termination: SMD/SMT; Supplier Part Number 1: 311-1.00KLRDKR-ND; Supplier 1: Digi-Key; Stock 1: 46596346; Simulation: RESISTOR; Signal Integrity: Res; REACH SVHC: Unknown; Published: 8-Jun-2000; Pricing 1: 1=0.1, 10=0.016, 100=0.0064, 1000=0.00289, 2500=0.00251, 5000=0.00207 (USD); Packaging: Tape and Reel; PackageReference: AXIAL-0.3; PackageDescription: Axial Device, Thru-Hole; 2 Leads; 0.3 in Pin Spacing; Number of Pins: 2; Min Operating Temperature: -55°C; Military Standard: Not; Max Operating Temperature: 155°C; Library Name: Miscellaneous Devices.IntLib; Length: 1mm; Lead Free: Lead Free; LatestRevisionNote: Re-released for DXP Platform.; LatestRevisionDate: 17-Jul-2002; Height: 0.32mm; Depth: 0.5mm; Composition: Thick Film; ComponentLink5URL: http://www.farnell.com/datasheets/2167052.pdf?src-supplier=Element14; ComponentLink5Description: http://www.farnell.com/datasheets/2167052.pdf?src-supplier=Element14; ComponentLink4URL: http://www.farnell.com/datasheets/1018594.pdf?src-supplier=Element14; ComponentLink4Description: http://www.farnell.com/datasheets/1018594.pdf?src-supplier=Element14; ComponentLink3URL: http://www.yageo.com/documents/recent/PYu-RC0402_51_RoHS_L_6_r.pdf?src-supplier=Future+Electronics; ComponentLink3Description: http://www.yageo.com/documents/recent/PYu-RC0402_51_RoHS_L_6_r.pdf?src-supplier=Future+Electronics; ComponentLink2URL: http://www.mouser.com/ds/2/447/PYu-RC0402_51_RoHS_L_6_r-8721.pdf?src-supplier=Mouser; ComponentLink2Description: http://www.mouser.com/ds/2/447/PYu-RC0402_51_RoHS_L_6_r-8721.pdf?src-supplier=Mouser; ComponentLink1URL: http://documents.rs-components.com/techlib://itc_doclist_GB.htm?stockno=6186122&src-supplier=RSComponents; ComponentLink1Description: http://documents.rs-components.com/techlib://itc_doclist_GB.htm?stockno=6186122&src-supplier=RSComponents; Component Kind: Standard; Case Code (Metric): 1005; Case Code (Imperial): 0402; Case/Package: 0402; Publisher: Altium Limited
R14 = Res1: Resistor; Manufacturer Part Number: RC0402JR-07470KL; Manufacturer: Yageo; Working Voltage: 50V; Tolerance: 5%; Temperature Coefficient: 100ppm/°C; Resistance: 470kR; Power Rating: 62.5mW; Max Power Dissipation: 63mW; Width: 0.02inch; Voltage Rating: 50V; Termination: SMD/SMT; Supplier Part Number 1: 311-470KJRDKR-ND; Supplier 1: Digi-Key; Stock 1: 1670677; Simulation: RESISTOR; Signal Integrity: Res; REACH SVHC: No SVHC; Published: 8-Jun-2000; Pricing 1: 1=0.1, 10=0.013, 100=0.0053, 1000=0.00236, 2500=0.00205, 5000=0.00169 (USD); Packaging: Tape and Reel; PackageReference: AXIAL-0.3; Package Quantity: 10000; PackageDescription: Axial Device, Thru-Hole; 2 Leads; 0.3 in Pin Spacing; Number of Terminations: 2; Min Operating Temperature: -55°C; Military Standard: Not; Max Operating Temperature: 155°C; Library Name: Miscellaneous Devices.IntLib; Length: 1mm; Lead Free: Lead Free; LatestRevisionNote: Re-released for DXP Platform.; LatestRevisionDate: 17-Jul-2002; Height: 0.016inch; Features: Moisture Resistant; Depth: 0.5mm; Composition: Thick Film; ComponentLink4URL: http://www.mouser.com/ds/2/447/PYu-RC0402_51_RoHS_L_6_r-8721.pdf?src-supplier=Mouser; ComponentLink4Description: http://www.mouser.com/ds/2/447/PYu-RC0402_51_RoHS_L_6_r-8721.pdf?src-supplier=Mouser; ComponentLink3URL: http://www.yageo.com/documents/recent/PYu-RC_Group_51_RoHS_L_9.pdf?src-supplier=Digi-Key; ComponentLink3Description: http://www.yageo.com/documents/recent/PYu-RC_Group_51_RoHS_L_9.pdf?src-supplier=Digi-Key; ComponentLink2URL: http://www.farnell.com/datasheets/2167052.pdf?src-supplier=Element14; ComponentLink2Description: http://www.farnell.com/datasheets/2167052.pdf?src-supplier=Element14; ComponentLink1URL: http://www.yageo.com/documents/recent/PYu-RC_Group_51_RoHS_L_10.pdf?src-supplier=Digi-Key; ComponentLink1Description: http://www.yageo.com/documents/recent/PYu-RC_Group_51_RoHS_L_10.pdf?src-supplier=Digi-Key; Component Kind: Standard; Case Code (Metric): 1005; Case Code (Imperial): 0402; Case/Package: 0402; Publisher: Altium Limited
SW1 SW2 = PTS810SJK250SMTRLFS: Manufacturer: ITT C&K; Throw Configuration: SPST; Switch Travel: 0.15mm; Switch Function: Off-Mom; Operating Force: 260gf; Mechanical Life: 50000Cycles; Max Current Rating: 50mA; Illumination Type: Non-Illuminated; Actuator Type: Standard; Voltage Rating (DC): 16V; Termination: J-Bend; Supplier Part Number 1: CKN10503DKR-ND; Supplier 1: Digi-Key; Stock 1: 40646; Pricing 1: 1=0.3, 10=0.287, 25=0.2768, 50=0.267, 100=0.2521, 250=0.23728, 500=0.2274, 1000=0.17302 (USD); Packaging: Tape and Reel; Package Quantity: 2900; Orientation: Straight; Mount: Surface Mount; Min Operating Temperature: -40°C; Max Operating Temperature: 85°C; Manufacturer Part Number: PTS810SJK250SMTRLFS; Library Name: SchlibEEG.SchLib; Length: 3.2mm; Lead Free: Lead Free; Description: SWITCH TACTILE SPST-NO 0.05A 16V; Depth: 4.6mm; Current Rating: 50mA; Contact Plating: Silver; ComponentLink1URL: https://www.ckswitches.com/media/1476/pts810.pdf?src-supplier=Digi-Key; ComponentLink1Description: https://www.ckswitches.com/media/1476/pts810.pdf?src-supplier=Digi-Key; Component Kind: Standard; Color: White; Case/Package: SMD/SMT
SW3 = 450404015514: MINI SPDT SLIDE SWITCH 6.7 X 2.7 MM   PINS 4-9 ARE MOUNTING; Manufacturer: Wurth Electronics; Supplier Part Number 1: 732-13665-6-ND; Supplier 1: Digi-Key; Stock 1: 2610; Pricing 1: 1=0.75, 25=0.7212, 50=0.688, 250=0.622, 1500=0.5461 (USD); Manufacturer Part Number: 450404015514; ComponentLink1URL: https://www.digikey.ca/product-detail/en/w-rth-elektronik/450404015514/732-13665-1-ND/9950835; ComponentLink1Description: digikey; Component Kind: Standard; Library Name: daniel_schlib.schlib
U1 = ADS1299IPAGR: IC AFE 24BIT 16KSPS LN 64TQFP; Manufacturer: Texas Instruments; Signal to Noise Ratio (SNR): 121dB; Sampling Rate: 16KS/s; Resolution: 24b; Power Consumption: 41mW; Operating Supply Current: 7.14mA; Number of Inputs: 8; Number of Bits: 24; Max Supply Voltage: 5.25V; Max Power Dissipation: 42mW; Interface: SPI; Type: General Purpose; Supplier Part Number 1: 296-34818-6-ND; Supplier 1: Digi-Key; Stock 1: 1589; Radiation Hardening: No; Pricing 1: 1=53.84, 10=50.577, 100=44.051 (USD); Packaging: Tape and Reel; Package Quantity: 1; Number of Pins: 64; Number of Converters: 8; Number of Channels: 8; Number of A/D Converters: 8; Min Operating Temperature: -40°C; Max Operating Temperature: 85°C; Manufacturer Part Number: ADS1299IPAGR; Lead Free: Lead Free; Conversion Rate: 16KS/s; Contact Plating: Gold; ComponentLink5URL: http://www.produktinfo.conrad.com/datenblaetter/1100000-1199999/001186463-da-01-en-IC_AFE_24BIT_16_ADS1299IPAGR_TQFP_64_TID.pdf?src-supplier=Conrad; ComponentLink5Description: http://www.produktinfo.conrad.com/datenblaetter/1100000-1199999/001186463-da-01-en-IC_AFE_24BIT_16_ADS1299IPAGR_TQFP_64_TID.pdf?src-supplier=Conrad; ComponentLink4URL: http://www.ti.com/litv/sbas499a?src-supplier=Mouser; ComponentLink4Description: http://www.ti.com/litv/sbas499a?src-supplier=Mouser; ComponentLink3URL: https://www.verical.com/server-webapp/html/getDatasheet?partID=681922&src-supplier=Verical; ComponentLink3Description: https://www.verical.com/server-webapp/html/getDatasheet?partID=681922&src-supplier=Verical; ComponentLink2URL: http://www.ti.com/general/docs/lit/getliterature.tsp?genericPartNumber=ADS1299&&fileType=pdf&src-supplier=Avnet; ComponentLink2Description: http://www.ti.com/general/docs/lit/getliterature.tsp?genericPartNumber=ADS1299&&fileType=pdf&src-supplier=Avnet; ComponentLink1URL: http://www.ti.com/lit/ds/symlink/ads1299.pdf?src-supplier=Digi-Key; ComponentLink1Description: http://www.ti.com/lit/ds/symlink/ads1299.pdf?src-supplier=Digi-Key; Component Kind: Standard; Category: Data Acquisition - Analog Front End (AFE); Case/Package: TQFP; Library Name: daniel_schlib.schlib
U2 = AP3417CKTR-G1: IC REG BUCK ADJ 1A SYNC SOT25; Manufacturer: Diodes; Synchronous Rectifier: Yes; Switching Frequency: 1.5MHz; Output Voltage: 5V; Output Type: Adjustable; Output Configuration: Positive; Operating Supply Voltage: 5.5V; Operating Supply Current: 220uA; Number of Outputs: 1; Min Supply Voltage: 2.5V; Min Output Voltage: 600mV; Max Output Voltage: 4.95V; Efficiency: 94%; Width: 1.7mm; Weight: 0.001058oz; Supplier Part Number 1: AP3417CKTR-G1DIDKR-ND; Supplier 1: Digi-Key; Stock 1: 30373; Pricing 1: 1=0.41, 10=0.351, 100=0.2621, 500=0.20592, 1000=0.15912 (USD); Packaging: Tape and Reel; Package Quantity: 3000; Output Current: 1A; Number of Pins: 5; Mount: Surface Mount; Min Operating Temperature: -40°C; Min Input Voltage: 2.5V; Max Output Current: 1A; Max Operating Temperature: 85°C; Max Input Voltage: 5.5V; Manufacturer Part Number: AP3417CKTR-G1; Library Name: SchlibEEG.SchLib; Length: 3.1mm; Lead Free: Lead Free; Height: 1.3mm; ComponentLink2URL: http://www.diodes.com/datasheets/AP3417C.pdf?src-supplier=Avnet; ComponentLink2Description: http://www.diodes.com/datasheets/AP3417C.pdf?src-supplier=Avnet; ComponentLink1URL: https://www.diodes.com/assets/Datasheets/AP3417C.pdf?src-supplier=Digi-Key; ComponentLink1Description: https://www.diodes.com/assets/Datasheets/AP3417C.pdf?src-supplier=Digi-Key; Component Kind: Standard; Case/Package: SOT-23-5
U3 U6 U9 = EXB-N8V162JX: Manufacturer: Panasonic; Voltage Rating (DC): 100V; Voltage Rating: 50V; Tolerance: 5%; Temperature Coefficient: 200ppm/°C; Resistance: 1.6kR; Power Per Element: 31mW; Number of Resistors: 4; Number of Contacts: 8; Circuit Type: Isolated; Width: 1mm; Termination: SMD/SMT; Terminal Pitch: 0.5mm; Supplier Part Number 1: Y10162DKR-ND; Supplier 1: Digi-Key; Stock 1: 21369; Radiation Hardening: No; Pricing 1: 1=0.11, 10=0.094, 25=0.0652, 50=0.0508, 100=0.0363, 250=0.02832, 500=0.0225, 1000=0.01597, 5000=0.01234 (USD); Packaging: Tape and Reel; Package Quantity: 1; Number of Terminals: 8; Number of Pins: 8; Mount: Surface Mount; Min Operating Temperature: -55°C; Max Operating Temperature: 125°C; Manufacturer Part Number: EXB-N8V162JX; Library Name: SchlibEEG.SchLib; Length: 0.079inch; Lead Free: Contains Lead; Height: 0.022inch; Description: RES ARRAY 4 RES 1.6K OHM 0804; ComponentLink4URL: https://industrial.panasonic.com/cdbs/www-data/pdf/AOC0000/AOC0000C14.pdf?src-supplier=Digi-Key; ComponentLink4Description: https://industrial.panasonic.com/cdbs/www-data/pdf/AOC0000/AOC0000C14.pdf?src-supplier=Digi-Key; ComponentLink3URL: http://www.onlinecomponents.com/datasheet/exb-n8v162jx.aspx?p=44463680&src-supplier=Onlinecomponents.com; ComponentLink3Description: http://www.onlinecomponents.com/datasheet/exb-n8v162jx.aspx?p=44463680&src-supplier=Onlinecomponents.com; ComponentLink2URL: http://industrial.panasonic.com/www-data/pdf/AOC0000/AOC0000CE1.pdf?src-supplier=Avnet; ComponentLink2Description: http://industrial.panasonic.com/www-data/pdf/AOC0000/AOC0000CE1.pdf?src-supplier=Avnet; ComponentLink1URL: http://www.mouser.com/ds/2/315/AOC0000CE1-49292.pdf?src-supplier=Mouser; ComponentLink1Description: http://www.mouser.com/ds/2/315/AOC0000CE1-49292.pdf?src-supplier=Mouser; Component Kind: Standard; Case/Package: 0402
U4 = TPS61073DDCR: IC REG BOOST ADJ 0.5A SYNC SOT6; Manufacturer: Texas Instruments; Synchronous Rectifier: Yes; Switching Frequency: 1.2MHz; Quiescent Current: 19uA; Output Voltage: 5.5V; Output Type: Adjustable; Output Current: 150mA; Output Configuration: Positive; Number of Outputs: 1; Min Supply Voltage: 2.3V; Min Input Voltage: 900mV; Efficiency: 90%; Weight: 0.00127oz; Termination: SMD/SMT; Supplier Part Number 1: 296-21898-2-ND; Supplier 1: Digi-Key; Stock 1: 6000; REACH SVHC: No SVHC; Pricing 1: 3000=0.52668, 6000=0.50688, 15000=0.49104 (USD); Packaging: Tape and Reel; Package Quantity: 1; Number of Pins: 6; Mount: Surface Mount; Min Output Voltage: 2.3V; Min Operating Temperature: -40°C; Max Supply Voltage: 5.5V; Max Output Voltage: 5.5V; Max Output Current: 150mA; Max Operating Temperature: 85°C; Max Input Voltage: 5.5V; Manufacturer Part Number: TPS61073DDCR; Lead Free: Lead Free; Frequency: 1.2MHz; ComponentLink2URL: http://www.ti.com/lit/ds/symlink/tps61073.pdf?src-supplier=Digi-Key; ComponentLink2Description: http://www.ti.com/lit/ds/symlink/tps61073.pdf?src-supplier=Digi-Key; ComponentLink1URL: http://focus.ti.com/lit/ds/symlink/tps61070.pdf?src-supplier=Element14; ComponentLink1Description: http://focus.ti.com/lit/ds/symlink/tps61070.pdf?src-supplier=Element14; Component Kind: Standard; Category: PMIC - Voltage Regulators - DC DC Switching Regulators; Case/Package: SOT-23-6; Library Name: daniel_schlib.schlib
U5 U7 = CA0612KRX7R9BB102: YAGEO (PHYCOMP)         CA0612KRX7R9BB102             Capacitor Array, C-Array, 1000 pF, 50 V,  10%, SMD, 4 Element; Number of Elements: 4; Insulation Resistance: 10GR; Dissipation Factor: 2.5%; Dielectric Material: Ceramic; Circuit Type: Isolated; Capacitance: 1nF; Width: 0.063inch; Voltage Rating: 50V; Voltage: 50V; Tolerance: 10%; Termination: SMD/SMT; Terminal Pitch: 0.8mm; Supplier Part Number 1: 311-2073-6-ND; Supplier 1: Digi-Key; Stock 1: 2369; REACH SVHC: Unknown; Radiation Hardening: No; Pricing 1: 1=0.41, 10=0.283, 100=0.1697, 500=0.12728, 1000=0.10749 (USD); Packaging: Tape and Reel; Package Quantity: 4000; Number of Terminals: 8; Number of Capacitors: 4; Mount: Surface Mount; Min Operating Temperature: -55°C; Max Operating Temperature: 125°C; Manufacturer Part Number: CA0612KRX7R9BB102; Manufacturer: Yageo; Library Name: SchlibEEG.SchLib; Length: 3.2mm; Lead Free: Lead Free; Height - Seated (Max): 0.035inch; Height: 0.8mm; Dielectric: X7R; Depth: 3.2mm; Composition: Ceramic; ComponentLink4URL: http://www.yageo.com/documents/recent/UPY-4C-Array_16V-to-50V_4.pdf?src-supplier=Future+Electronics; ComponentLink4Description: http://www.yageo.com/documents/recent/UPY-4C-Array_16V-to-50V_4.pdf?src-supplier=Future+Electronics; ComponentLink3URL: http://www.farnell.com/datasheets/2167451.pdf?src-supplier=Element14; ComponentLink3Description: http://www.farnell.com/datasheets/2167451.pdf?src-supplier=Element14; ComponentLink2URL: http://www.yageo.com/documents/recent/UPY-4C-Array_16V-to-50V-3.pdf?src-supplier=Digi-Key; ComponentLink2Description: http://www.yageo.com/documents/recent/UPY-4C-Array_16V-to-50V-3.pdf?src-supplier=Digi-Key; ComponentLink1URL: https://www.verical.com/server-webapp/html/getDatasheet?partID=403419&src-supplier=Verical; ComponentLink1Description: https://www.verical.com/server-webapp/html/getDatasheet?partID=403419&src-supplier=Verical; Component Kind: Standard; Case Code (Metric): 1632; Case Code (Imperial): 0612; Case/Package: 0612
U8 = PIC32MX250F128B-I/SS: MICROCHIP - PIC32MX250F128B-I/SS - MCU, 32BIT, PIC32, 40MHZ, SSOP-28; Watchdog Timer: Yes; RAM Size: 32KB; Peripherals: I2C,Brown-out Detect/Reset,POR,PWM,WDT,DMA; Oscillator Type: Internal; Number of UART Channels: 2; Number of Timers/Counters: 5; Number of Programmable I/O: 19; Number of ADC Channels: 10; Min Supply Voltage: 2.3V; Memory Type: FLASH; Memory Size: 128KB; Max Supply Voltage: 3.6V; Interface: I2C,SPI,USB,IrDA,UART,USART,LIN,I2S; Data Bus Width: 32b; Core Architecture: PIC; Width: 5.6mm; Supplier Part Number 1: PIC32MX250F128B-I/SS-ND; Supplier 1: Digi-Key; Stock 1: 861; REACH SVHC: No SVHC; Radiation Hardening: No; Pricing 1: 1=3.57, 25=3.27, 100=2.96 (USD); Packaging: Rail/Tube; Package Quantity: 47; Number of SPI Channels: 2; Number of PWM Channels: 5; Number of Pins: 28; Number of I/Os: 19; Number of I2C Channels: 2; Min Operating Temperature: -40°C; Max Operating Temperature: 85°C; Max Frequency: 40MHz; Manufacturer Part Number: PIC32MX250F128B-I/SS; Manufacturer: Microchip; Library Name: SchlibEEG.SchLib; Length: 10.5mm; Lead Free: Lead Free; Height: 1.85mm; Frequency: 40MHz; ComponentLink5URL: http://www.farnell.com/datasheets/1538023.pdf?src-supplier=Element14; ComponentLink5Description: http://www.farnell.com/datasheets/1538023.pdf?src-supplier=Element14; ComponentLink4URL: http://ww1.microchip.com/downloads/en/DeviceDoc/61168D.pdf?src-supplier=Avnet; ComponentLink4Description: http://ww1.microchip.com/downloads/en/DeviceDoc/61168D.pdf?src-supplier=Avnet; ComponentLink3URL: http://www.microchip.com/mymicrochip/filehandler.aspx?ddocname=en556114&src-supplier=Digi-Key; ComponentLink3Description: http://www.microchip.com/mymicrochip/filehandler.aspx?ddocname=en556114&src-supplier=Digi-Key; ComponentLink2URL: http://uk.rs-online.com/webdocs/1385/0900766b8138557c.pdf?src-supplier=RSComponents; ComponentLink2Description: http://uk.rs-online.com/webdocs/1385/0900766b8138557c.pdf?src-supplier=RSComponents; ComponentLink1URL: https://www.distrelec.biz/Web/Downloads/_t/ds/PIC32MX1XX_2XX_eng_tds.pdf?mime=application%2Fpdf&src-supplier=Distrelec; ComponentLink1Description: https://www.distrelec.biz/Web/Downloads/_t/ds/PIC32MX1XX_2XX_eng_tds.pdf?mime=application%2Fpdf&src-supplier=Distrelec; Component Kind: Standard; Case/Package: SSOP; Address Bus Width: 32b
